# Altium schematic sheet FPGA.SchDoc(FPGA)
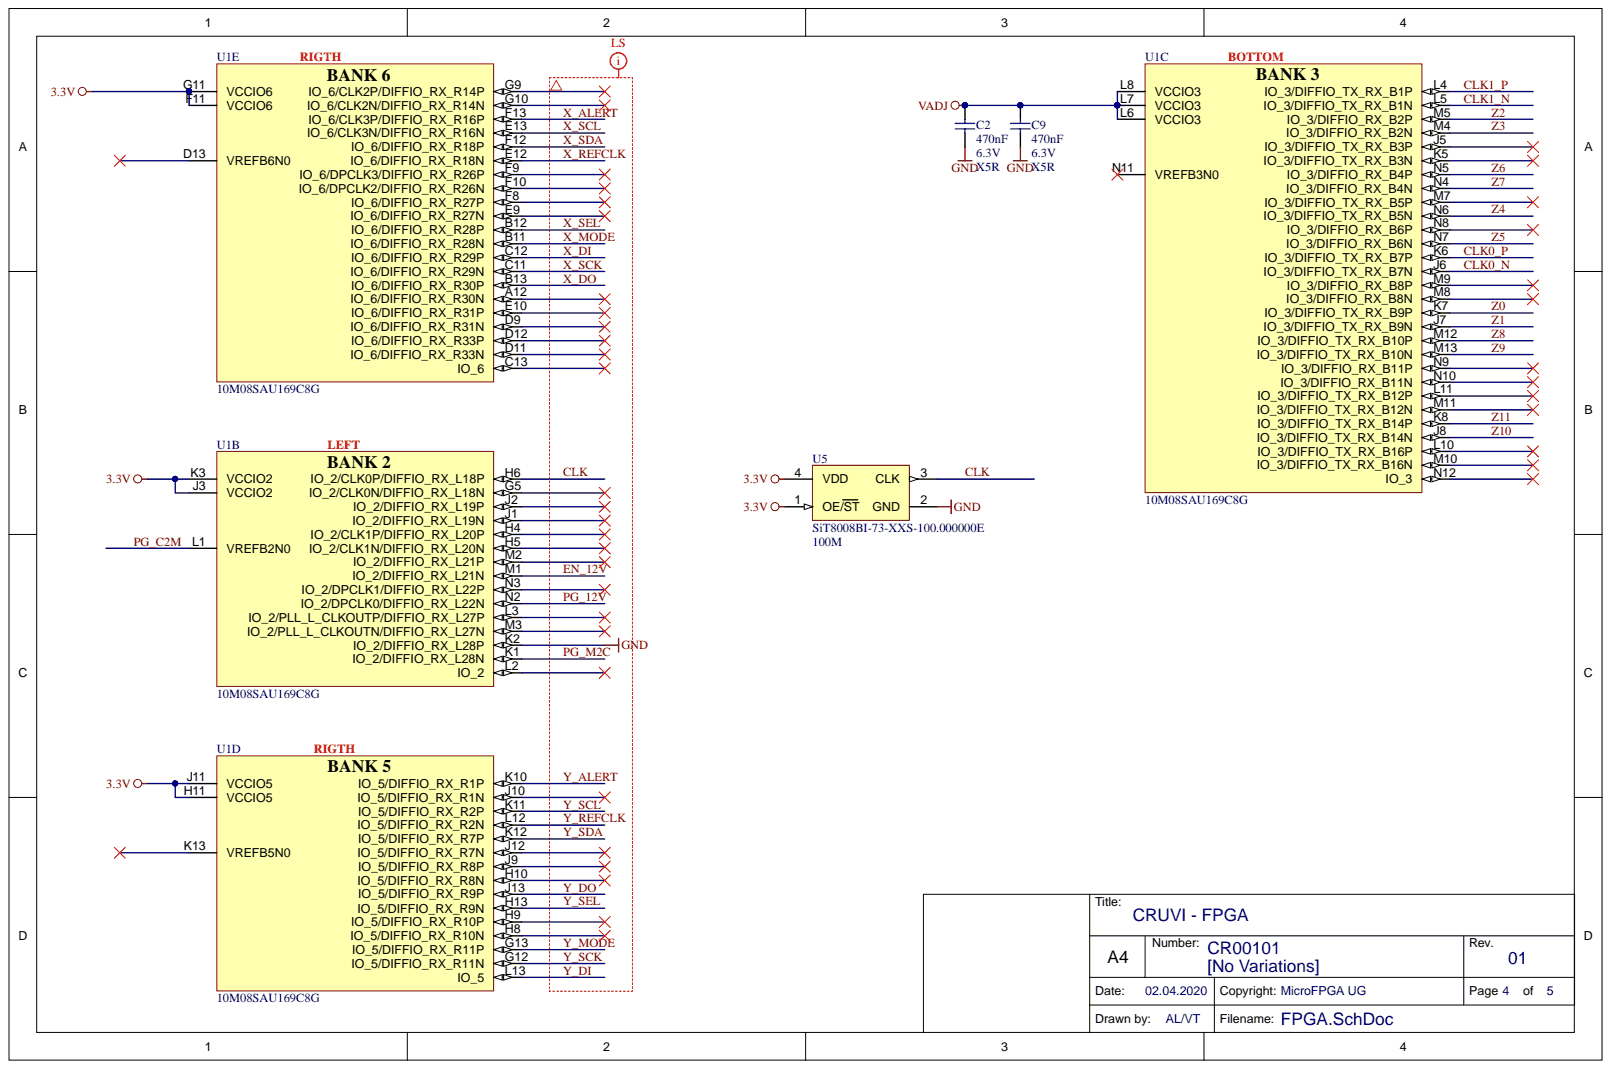
[diagram p0: FPGA.SchDoc]

## Parts
C2  470nF  Technology: X5R  Voltage: 6.3V  [CAPC0603X33N]
C9  470nF  Technology: X5R  Voltage: 6.3V  [CAPC0603X33N]
U1  10M08SAU169C8G  [BGA169C80P13X13_11X11X15]  (parts B,C,D,E)
U5  SiT8008BI-73-XXS-100.000000E  value 100M  Database Table Name: CLK  [2016]

## Nets
3.3V: U1.F11(VCCIO6) U1.G11(VCCIO6) U1.H11(VCCIO5) U1.J3(VCCIO2) U1.J11(VCCIO5) U1.K3(VCCIO2) U5.1(OE/ST) U5.4(VDD)
GND: C2.2 C9.2 U1.K2(IO_2/DIFFIO_RX_L28P) U5.2(GND)
VADJ: C2.1 C9.1 U1.L6(VCCIO3) U1.L7(VCCIO3) U1.L8(VCCIO3)
CLK: U1.H6(IO_2/CLK0P/DIFFIO_RX_L18P) U5.3(CLK)
CLK0_N: U1.J6(IO_3/DIFFIO_TX_RX_B7N)
CLK0_P: U1.K6(IO_3/DIFFIO_TX_RX_B7P)
CLK1_N: U1.L5(IO_3/DIFFIO_TX_RX_B1N)
CLK1_P: U1.L4(IO_3/DIFFIO_TX_RX_B1P)
EN_12V: U1.M1(IO_2/DIFFIO_RX_L21N)
PG_12V: U1.N2(IO_2/DPCLK0/DIFFIO_RX_L22N)
PG_C2M: U1.L1(VREFB2N0)
PG_M2C: U1.K1(IO_2/DIFFIO_RX_L28N)
X_ALERT: U1.F13(IO_6/CLK3P/DIFFIO_RX_R16P)
X_DI: U1.C12(IO_6/DIFFIO_RX_R29P)
X_DO: U1.B13(IO_6/DIFFIO_RX_R30P)
X_MODE: U1.B11(IO_6/DIFFIO_RX_R28N)
X_REFCLK: U1.E12(IO_6/DIFFIO_RX_R18N)
X_SCK: U1.C11(IO_6/DIFFIO_RX_R29N)
X_SCL: U1.E13(IO_6/CLK3N/DIFFIO_RX_R16N)
X_SDA: U1.F12(IO_6/DIFFIO_RX_R18P)
X_SEL: U1.B12(IO_6/DIFFIO_RX_R28P)
Y_ALERT: U1.K10(IO_5/DIFFIO_RX_R1P)
Y_DI: U1.L13(IO_5)
Y_DO: U1.J13(IO_5/DIFFIO_RX_R9P)
Y_MODE: U1.G13(IO_5/DIFFIO_RX_R11P)
Y_REFCLK: U1.L12(IO_5/DIFFIO_RX_R2N)
Y_SCK: U1.G12(IO_5/DIFFIO_RX_R11N)
Y_SCL: U1.K11(IO_5/DIFFIO_RX_R2P)
Y_SDA: U1.K12(IO_5/DIFFIO_RX_R7P)
Y_SEL: U1.H13(IO_5/DIFFIO_RX_R9N)
Z0: U1.K7(IO_3/DIFFIO_TX_RX_B9P)
Z1: U1.J7(IO_3/DIFFIO_TX_RX_B9N)
Z2: U1.M5(IO_3/DIFFIO_RX_B2P)
Z3: U1.M4(IO_3/DIFFIO_RX_B2N)
Z4: U1.N6(IO_3/DIFFIO_TX_RX_B5N)
Z5: U1.N7(IO_3/DIFFIO_RX_B6N)
Z6: U1.N5(IO_3/DIFFIO_RX_B4P)
Z7: U1.N4(IO_3/DIFFIO_RX_B4N)
Z8: U1.M12(IO_3/DIFFIO_TX_RX_B10P)
Z9: U1.M13(IO_3/DIFFIO_TX_RX_B10N)
Z10: U1.J8(IO_3/DIFFIO_TX_RX_B14N)
Z11: U1.K8(IO_3/DIFFIO_TX_RX_B14P)
N00349:

Not connected: U1 A12(IO_6/DIFFIO_RX_R30N) C13(IO_6) D9(IO_6/DIFFIO_RX_R31N) D11(IO_6/DIFFIO_RX_R33N) D12(IO_6/DIFFIO_RX_R33P) D13(VREFB6N0) E9(IO_6/DIFFIO_RX_R27N) E10(IO_6/DIFFIO_RX_R31P) F8(IO_6/DIFFIO_RX_R27P) F9(IO_6/DPCLK3/DIFFIO_RX_R26P) F10(IO_6/DPCLK2/DIFFIO_RX_R26N) G5(IO_2/CLK0N/DIFFIO_RX_L18N) G9(IO_6/CLK2P/DIFFIO_RX_R14P) G10(IO_6/CLK2N/DIFFIO_RX_R14N) H4(IO_2/CLK1P/DIFFIO_RX_L20P) H5(IO_2/CLK1N/DIFFIO_RX_L20N) H8(IO_5/DIFFIO_RX_R10N) H9(IO_5/DIFFIO_RX_R10P) H10(IO_5/DIFFIO_RX_R8N) J1(IO_2/DIFFIO_RX_L19N) J2(IO_2/DIFFIO_RX_L19P) J5(IO_3/DIFFIO_TX_RX_B3P) J9(IO_5/DIFFIO_RX_R8P) J10(IO_5/DIFFIO_RX_R1N) J12(IO_5/DIFFIO_RX_R7N) K5(IO_3/DIFFIO_TX_RX_B3N) K13(VREFB5N0) L2(IO_2) L3(IO_2/PLL_L_CLKOUTP/DIFFIO_RX_L27P) L10(IO_3/DIFFIO_TX_RX_B16P) L11(IO_3/DIFFIO_TX_RX_B12P) M2(IO_2/DIFFIO_RX_L21P) M3(IO_2/PLL_L_CLKOUTN/DIFFIO_RX_L27N) M7(IO_3/DIFFIO_TX_RX_B5P) M8(IO_3/DIFFIO_RX_B8N) M9(IO_3/DIFFIO_RX_B8P) M10(IO_3/DIFFIO_TX_RX_B16N) M11(IO_3/DIFFIO_TX_RX_B12N) N3(IO_2/DPCLK1/DIFFIO_RX_L22P) N8(IO_3/DIFFIO_RX_B6P) N9(IO_3/DIFFIO_RX_B11P) N10(IO_3/DIFFIO_RX_B11N) N11(VREFB3N0) N12(IO_3)

## Library data of the parts
C2 C9 = 24921: KON 470nF 6.3V X5R 20%  SMD BF 0603 (0201); Database Table Name: C_0603_0201; Mixed: SMT; Preferred: Yes; Temperature-Range: -55/+85; Value: 470n; AN: 24921; Case: 0603 (0201); Component Kind: Standard; Library Name: TE.DbLib; Tolerance: 20%
U1 = 27930: Database Table Name: PROG_LOGIC; Mixed: SMT; Temperature-Range: 0/+85 (Tj); AN: 27930; Case: LFBGA169; Component Kind: Standard; Description: 10M08SAU169C8G; H-ART: 10M08SAU169C8G; Library Name: TE.DbLib; Storage-Temperature: -65/+150(Abs); HelpURL: b:\AD\adlib\datasheet\ALTERA_MAX10\
U5 = 25961: SiT8008BI-73-XXS-100.000000E MEMS Oszillator 100MHz; Mixed: SMT; Preferred: Yes; AN: 25961; Case: 2.0  x 1.6mm; Component Kind: Standard; H-ART: SiT8008BI-73-XXS-100.000000E; Library Name: TE.DbLib; Trenz-Stock: Yes; HelpURL: B:\AD\adlib\datasheet\SiT8008
